# Altium schematic sheet keyboard.SchDoc
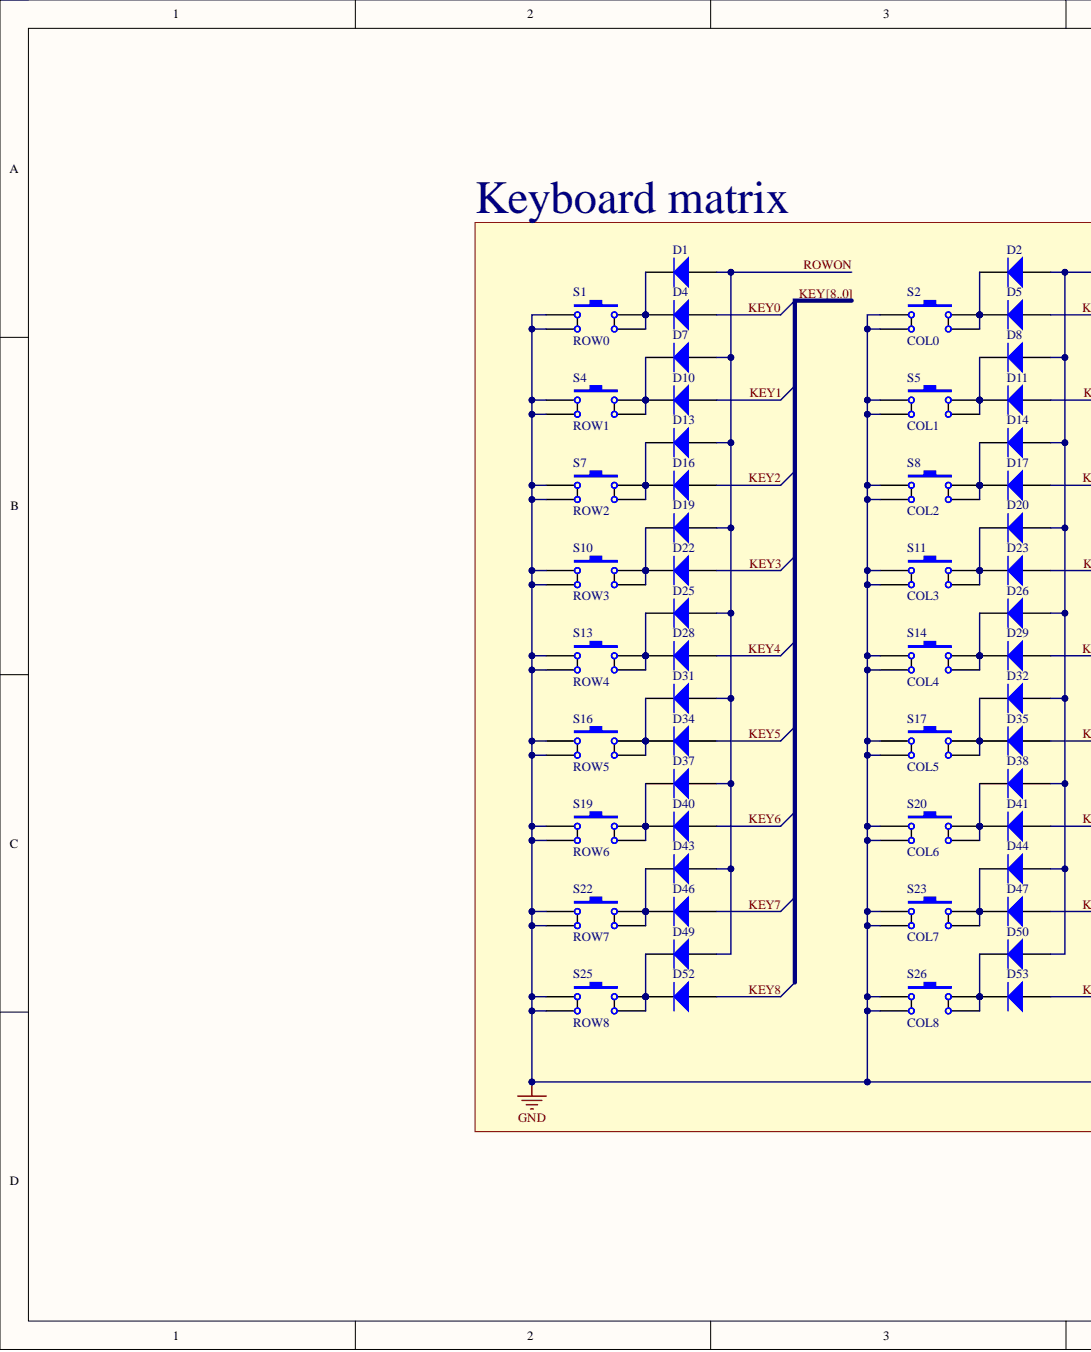
[diagram p0: keyboard.SchDoc, left]
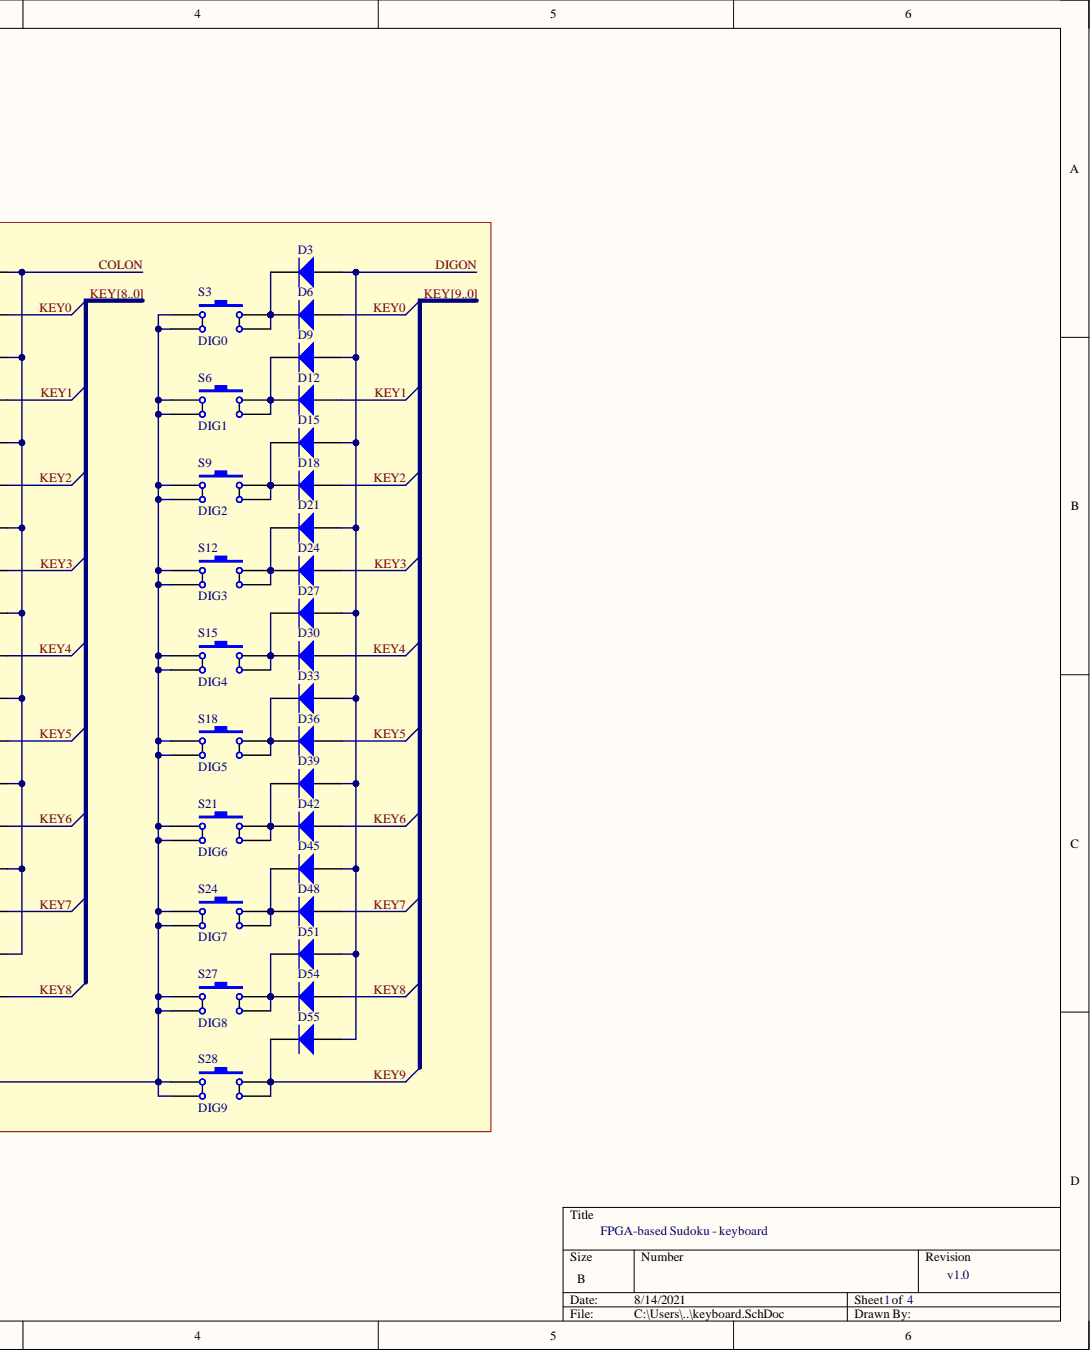
[diagram p1: keyboard.SchDoc, right]

## Parts
D1  Diode 1N4007  [DIODE_SMC]  (value not drawn)
D2  Diode 1N4007  [DIODE_SMC]  (value not drawn)
D3  Diode 1N4007  [DIODE_SMC]  (value not drawn)
D4  Diode 1N4007  [DIODE_SMC]  (value not drawn)
D5  Diode 1N4007  [DIODE_SMC]  (value not drawn)
D6  Diode 1N4007  [DIODE_SMC]  (value not drawn)
D7  Diode 1N4007  [DIODE_SMC]  (value not drawn)
D8  Diode 1N4007  [DIODE_SMC]  (value not drawn)
D9  Diode 1N4007  [DIODE_SMC]  (value not drawn)
D10  Diode 1N4007  [DIODE_SMC]  (value not drawn)
D11  Diode 1N4007  [DIODE_SMC]  (value not drawn)
D12  Diode 1N4007  [DIODE_SMC]  (value not drawn)
D13  Diode 1N4007  [DIODE_SMC]  (value not drawn)
D14  Diode 1N4007  [DIODE_SMC]  (value not drawn)
D15  Diode 1N4007  [DIODE_SMC]  (value not drawn)
D16  Diode 1N4007  [DIODE_SMC]  (value not drawn)
D17  Diode 1N4007  [DIODE_SMC]  (value not drawn)
D18  Diode 1N4007  [DIODE_SMC]  (value not drawn)
D19  Diode 1N4007  [DIODE_SMC]  (value not drawn)
D20  Diode 1N4007  [DIODE_SMC]  (value not drawn)
D21  Diode 1N4007  [DIODE_SMC]  (value not drawn)
D22  Diode 1N4007  [DIODE_SMC]  (value not drawn)
D23  Diode 1N4007  [DIODE_SMC]  (value not drawn)
D24  Diode 1N4007  [DIODE_SMC]  (value not drawn)
D25  Diode 1N4007  [DIODE_SMC]  (value not drawn)
D26  Diode 1N4007  [DIODE_SMC]  (value not drawn)
D27  Diode 1N4007  [DIODE_SMC]  (value not drawn)
D28  Diode 1N4007  [DIODE_SMC]  (value not drawn)
D29  Diode 1N4007  [DIODE_SMC]  (value not drawn)
D30  Diode 1N4007  [DIODE_SMC]  (value not drawn)
D31  Diode 1N4007  [DIODE_SMC]  (value not drawn)
D32  Diode 1N4007  [DIODE_SMC]  (value not drawn)
D33  Diode 1N4007  [DIODE_SMC]  (value not drawn)
D34  Diode 1N4007  [DIODE_SMC]  (value not drawn)
D35  Diode 1N4007  [DIODE_SMC]  (value not drawn)
D36  Diode 1N4007  [DIODE_SMC]  (value not drawn)
D37  Diode 1N4007  [DIODE_SMC]  (value not drawn)
D38  Diode 1N4007  [DIODE_SMC]  (value not drawn)
D39  Diode 1N4007  [DIODE_SMC]  (value not drawn)
D40  Diode 1N4007  [DIODE_SMC]  (value not drawn)
D41  Diode 1N4007  [DIODE_SMC]  (value not drawn)
D42  Diode 1N4007  [DIODE_SMC]  (value not drawn)
D43  Diode 1N4007  [DIODE_SMC]  (value not drawn)
D44  Diode 1N4007  [DIODE_SMC]  (value not drawn)
D45  Diode 1N4007  [DIODE_SMC]  (value not drawn)
D46  Diode 1N4007  [DIODE_SMC]  (value not drawn)
D47  Diode 1N4007  [DIODE_SMC]  (value not drawn)
D48  Diode 1N4007  [DIODE_SMC]  (value not drawn)
D49  Diode 1N4007  [DIODE_SMC]  (value not drawn)
D50  Diode 1N4007  [DIODE_SMC]  (value not drawn)
D51  Diode 1N4007  [DIODE_SMC]  (value not drawn)
D52  Diode 1N4007  [DIODE_SMC]  (value not drawn)
D53  Diode 1N4007  [DIODE_SMC]  (value not drawn)
D54  Diode 1N4007  [DIODE_SMC]  (value not drawn)
D55  Diode 1N4007  [DIODE_SMC]  (value not drawn)
S1  ROW0  [SW_1825910-6-4]
S2  COL0  [SW_1825910-6-4]
S3  DIG0  [SW_1825910-6-4]
S4  ROW1  [SW_1825910-6-4]
S5  COL1  [SW_1825910-6-4]
S6  DIG1  [SW_1825910-6-4]
S7  ROW2  [SW_1825910-6-4]
S8  COL2  [SW_1825910-6-4]
S9  DIG2  [SW_1825910-6-4]
S10  ROW3  [SW_1825910-6-4]
S11  COL3  [SW_1825910-6-4]
S12  DIG3  [SW_1825910-6-4]
S13  ROW4  [SW_1825910-6-4]
S14  COL4  [SW_1825910-6-4]
S15  DIG4  [SW_1825910-6-4]
S16  ROW5  [SW_1825910-6-4]
S17  COL5  [SW_1825910-6-4]
S18  DIG5  [SW_1825910-6-4]
S19  ROW6  [SW_1825910-6-4]
S20  COL6  [SW_1825910-6-4]
S21  DIG6  [SW_1825910-6-4]
S22  ROW7  [SW_1825910-6-4]
S23  COL7  [SW_1825910-6-4]
S24  DIG7  [SW_1825910-6-4]
S25  ROW8  [SW_1825910-6-4]
S26  COL8  [SW_1825910-6-4]
S27  DIG8  [SW_1825910-6-4]
S28  DIG9  [SW_1825910-6-4]

## Nets
GND: S1.3 S1.4 S2.3 S2.4 S3.3 S3.4 S4.3 S4.4 S5.3 S5.4 S6.3 S6.4 S7.3 S7.4 S8.3 S8.4 S9.3 S9.4 S10.3 S10.4 S11.3 S11.4 S12.3 S12.4 S13.3 S13.4 S14.3 S14.4 S15.3 S15.4 S16.3 S16.4 S17.3 S17.4 S18.3 S18.4 S19.3 S19.4 S20.3 S20.4 S21.3 S21.4 S22.3 S22.4 S23.3 S23.4 S24.3 S24.4 S25.3 S25.4 S26.3 S26.4 S27.3 S27.4 S28.3 S28.4
COLON: D2.1 D8.1 D14.1 D20.1 D26.1 D32.1 D38.1 D44.1 D50.1
DIGON: D3.1 D9.1 D15.1 D21.1 D27.1 D33.1 D39.1 D45.1 D51.1 D55.1
KEY0: D4.1 D5.1 D6.1  [also labelled KEY]
KEY1: D10.1 D11.1 D12.1  [also labelled KEY]
KEY2: D16.1 D17.1 D18.1  [also labelled KEY]
KEY3: D22.1 D23.1 D24.1  [also labelled KEY]
KEY4: D28.1 D29.1 D30.1  [also labelled KEY]
KEY5: D34.1 D35.1 D36.1  [also labelled KEY]
KEY6: D40.1 D41.1 D42.1  [also labelled KEY]
KEY7: D46.1 D47.1 D48.1  [also labelled KEY]
KEY8: D52.1 D53.1 D54.1  [also labelled KEY]
KEY9: D55.2 S28.1 S28.2  [also labelled KEY]
ROWON: D1.1 D7.1 D13.1 D19.1 D25.1 D31.1 D37.1 D43.1 D49.1
NetD1_2: D1.2 D4.2 S1.1 S1.2
NetD2_2: D2.2 D5.2 S2.1 S2.2
NetD3_2: D3.2 D6.2 S3.1 S3.2
NetD7_2: D7.2 D10.2 S4.1 S4.2
NetD8_2: D8.2 D11.2 S5.1 S5.2
NetD9_2: D9.2 D12.2 S6.1 S6.2
NetD13_2: D13.2 D16.2 S7.1 S7.2
NetD14_2: D14.2 D17.2 S8.1 S8.2
NetD15_2: D15.2 D18.2 S9.1 S9.2
NetD19_2: D19.2 D22.2 S10.1 S10.2
NetD20_2: D20.2 D23.2 S11.1 S11.2
NetD21_2: D21.2 D24.2 S12.1 S12.2
NetD25_2: D25.2 D28.2 S13.1 S13.2
NetD26_2: D26.2 D29.2 S14.1 S14.2
NetD27_2: D27.2 D30.2 S15.1 S15.2
NetD31_2: D31.2 D34.2 S16.1 S16.2
NetD32_2: D32.2 D35.2 S17.1 S17.2
NetD33_2: D33.2 D36.2 S18.1 S18.2
NetD37_2: D37.2 D40.2 S19.1 S19.2
NetD38_2: D38.2 D41.2 S20.1 S20.2
NetD39_2: D39.2 D42.2 S21.1 S21.2
NetD43_2: D43.2 D46.2 S22.1 S22.2
NetD44_2: D44.2 D47.2 S23.1 S23.2
NetD45_2: D45.2 D48.2 S24.1 S24.2
NetD49_2: D49.2 D52.2 S25.1 S25.2
NetD50_2: D50.2 D53.2 S26.1 S26.2
NetD51_2: D51.2 D54.2 S27.1 S27.2
KEY[8..0]:  [also labelled KEY]

## Library data of the parts
D1-D55 = Diode 1N4007: 1 Amp General Purpose Rectifier; Code_JEDEC: DO-204-AL; Component Kind: Standard; ComponentLink1Description: Manufacturer Link; ComponentLink1URL: http://www.fairchildsemi.com/; ComponentLink2Description: Datasheet; ComponentLink2URL: http://www.fairchildsemi.com/ds/1N%2F1N4001.pdf; DatasheetVersion: 1998; LatestRevisionDate: 17-Jul-2002; LatestRevisionNote: Re-released for DXP Platform.; Library Name: Miscellaneous Devices.IntLib; PackageDescription: Diode, Axial; Body 5.2 x 2.7 mm (LxDia. max), Lead Dia. 0.86 mm (max); PackageReference: DO-41; PackageVersion: Aug-1999; Published: 8-Jun-2000; Signal Integrity: 1N4007; Simulation: 1N4007; Publisher: Altium Limited
S1-S28 = SW-PB: Switch; Component Kind: Standard; LatestRevisionDate: 17-Jul-2002; LatestRevisionNote: Re-released for DXP Platform.; Library Name: tactile_button.SchLib; PackageDescription: Switch; 2 Leads; PackageReference: SPST-2; Published: 24-Mar-1999; Publisher: Altium Limited
